# Altium schematic sheet USB.SchDoc
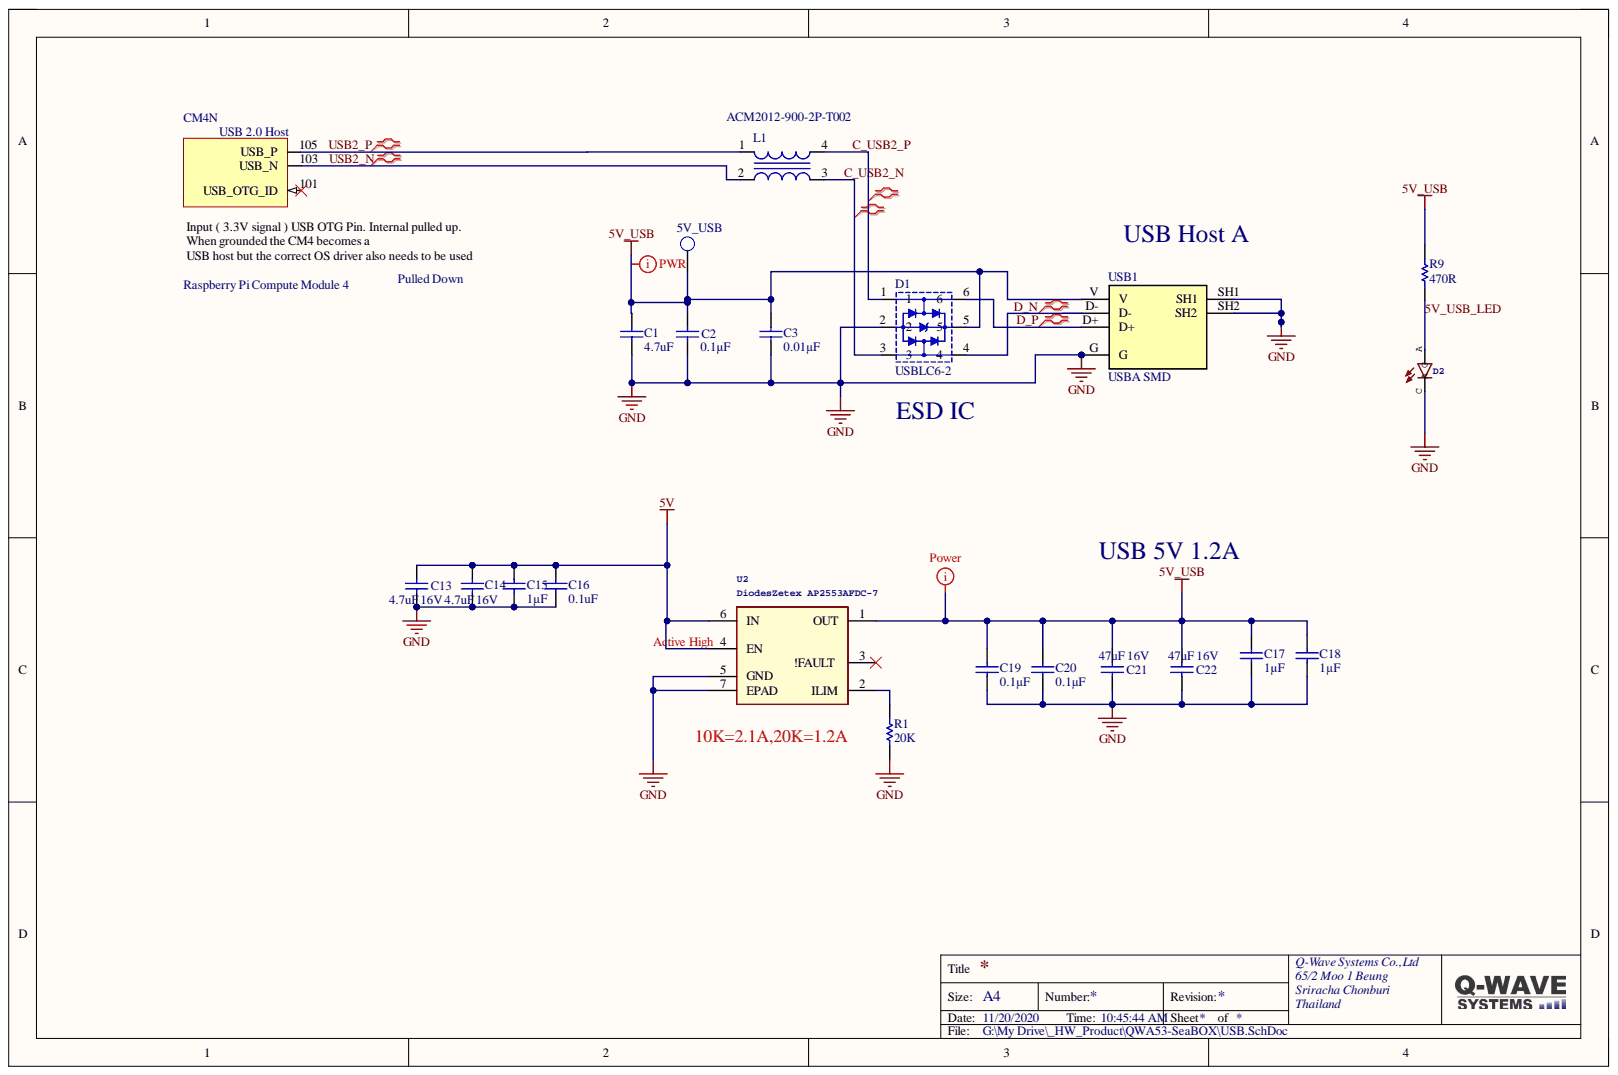
[diagram p0: USB.SchDoc]

## Parts
1  5V_USB  [TEST_POINT]
C1  4.7uF  [CAP_0805]
C2  0.1µF  [CAP_0603]
C3  0.01µF  [CAP_0603]
C13  4.7uF 16V  [CAP_0805]
C14  4.7uF 16V  [CAP_0805]
C15  1µF  [CAP_0603]
C16  0.1uF  [CAP_0603]
C17  1µF  [CAP_0603]
C18  1µF  [CAP_0603]
C19  0.1µF  [CAP_0603]
C20  0.1µF  [CAP_0603]
C21  47uF 16V  value 47uF  [1210C-GENERIC]
C22  47uF 16V  value 47uF  [1210C-GENERIC]
CM4  Raspberry Pi Compute Module 4  [CM4-F40C-100DS-0.4v_1.5mm_0mm]  (part N)
D1  USBLC6-2  [SOT-666]
D2  Red SMD LED    Used in manufacturing of most products at SparkFun   Packages: 0603 - SMD 0603 package [Product Link]  0603 SMART - SMD 0603 SMART package ( more efficient and expensive)[Product Link]   1206 - SMD1206  package [Product Link]    SparkFun Products: Pro Mini 328 -5V  RedBoard  IOIO-OTG  [LED-1206-LED_AL-S1204SRC50-14M]  (value not drawn)
L1  ACM2012-900-2P-T002  [ACM2012]
R1  20K  [RES_0603]
R9  470R  [RES_0603]
U2  DiodesZetex AP2553AFDC-7  [SON65P201X201X63-7N]
USB1  USBA SMD  [USB-A/S_B]

## Nets
5V: C13.1 C14.1 C15.2 C16.2 U2.4(EN) U2.6(IN)
5V_USB: 1.1 C1.1 C2.1 C3.2 C17.2 C18.2 C19.2 C20.2 C21.2 C22.2 D1.5 R9.2 U2.1(OUT) USB1.V
GND: C1.2 C2.2 C3.1 C13.2 C14.2 C15.1 C16.1 C17.1 C18.1 C19.1 C20.1 C21.1 C22.1 D1.2 D2.C R1.1 U2.5(GND) U2.7(EPAD) USB1.G USB1.SH1 USB1.SH2
5V_USB_LED: D2.A R9.1
C_USB2_N: D1.3 L1.3
C_USB2_P: D1.1 L1.4
D_N: D1.4 USB1.D-
D_P: D1.6 USB1.D+
USB2_N: CM4.103 L1.2
USB2_P: CM4.105 L1.1
NetR1_2: R1.2 U2.2(ILIM)

Not connected: CM4 101; U2 3(!FAULT)

## Library data of the parts
1 = TEST_POINT: Test Point; Component Kind: Standard; Library Name: Misc.SCHLIB
C1 C13 C14 = Cap: 4.7µF ±10% 16V Ceramic Capacitor X5R 0805; MFG: KEMET; MFG P/N: C0603C475K8PACTU; Component Kind: Standard; Library Name: Miscellaneous Devices.IntLib; Signal Integrity: Cap; Simulation: CAP
C2 C16 = Cap Semi: 0.1µF ±5% 50V Ceramic Capacitor X7R 0603; MFG: KEMET; MFG P/N: C0603C104J5RACTU; Component Kind: Standard; Library Name: Miscellaneous Devices.IntLib; Signal Integrity: Cap Semi; Simulation: CAP
C3 = Cap Semi: 0.01µF ±5% 50V Ceramic Capacitor X7R 0603; MFG: KEMET; MFG P/N: C0603C104J5RACTU; Component Kind: Standard; Library Name: Miscellaneous Devices.IntLib; Signal Integrity: Cap Semi; Simulation: CAP
C15 C17 C18 = Cap Semi: 1µF ±5% 50V Ceramic Capacitor X7R 0603; MFG: KEMET; MFG P/N: C0603C104J5RACTU; Component Kind: Standard; Library Name: Miscellaneous Devices.IntLib; Signal Integrity: Cap Semi; Simulation: CAP
C19 C20 = Cap Semi: 0.11µF ±5% 50V Ceramic Capacitor X7R 0603; MFG: KEMET; MFG P/N: C0603C104J5RACTU; Component Kind: Standard; Library Name: Miscellaneous Devices.IntLib; Signal Integrity: Cap Semi; Simulation: CAP
C21 C22 = Cap: Capacitor 1210; Part Number: 0805F476M100NT; Component Kind: Standard; LatestRevisionDate: 17-Jul-2002; LatestRevisionNote: Re-released for DXP Platform.; Library Name: Miscellaneous Devices.IntLib; PackageDescription: Radial Cap, Thru-Hole; 2 Leads; 0.3 in Pin Spacing; PackageReference: RAD-0.3; Published: 8-Jun-2000; Signal Integrity: Cap; Simulation: CAP; Supplier: LCSC; Publisher: Altium Limited
CM4 = CM4: Component Kind: Standard; Description: Raspberry Pi Compute Module 4; Library Name: CM4.SchLib
D1 = USBLC6-2: TVS DIODE 5.25V 17V SOT23-6; Component Kind: Standard; Library Name: x-io.SchLib
D2 = LED-RED1206: LED Red SMD ES P/N 0983-0003-2; DeviceName: 1206; GateName_1: G$1; PROD_ID: DIO-09912; Component Kind: Standard; DeviceSetName: LED-RED; Library Name: SparkFun-LED.IntLib; LibraryName: SparkFun-LED; SymbolName_1: LED
L1 = ACM2012-900-2P-T002: CHOKE COMM MODE 90 OHM .4A SMD; Manufacturer: TDK Corporation; .Checked PCB: RF; Current: 400mA; DC Resistance (DCR): 190 mOhm Max; Filter Type: Data, Signal Line; Impedance: 90 Ohm; Number of Lines: 2; Power (Watts): 0.063W, 1/16W; Resistance (Ohms): 8.2K; Temperature Coefficient: ฑ100ppm/ฐC; Tolerance: ฑ1%; Category: Filters; Component Kind: Standard; ComponentLink1Description: http://www.tdk.co.jp/tefe02/e9719_acm2012.pdf; ComponentLink1URL: http://www.tdk.co.jp/tefe02/e9719_acm2012.pdf; ComponentLink2Description: http://www.yageo.com/documents/recent/PYu-RC0402_51_RoHS_L_6.pdf; ComponentLink2URL: http://www.yageo.com/documents/recent/PYu-RC0402_51_RoHS_L_6.pdf; Composition: Thick Film; Features: -; Height: 0.016" (0.40mm); Height (Max): 0.051" (1.30mm); Inductance: -; Manufacturer Part Number: ACM2012-900-2P-T002; Mounting Type: Surface Mount; Number of Terminations: 2; Operating Temperature: -25ฐC ~ 85ฐC; Package / Case: 0805 (2012 Metric), 4 Lead; Packaging: Cut Tape (CT); Pricing 1: 1=0.48, 10=0.448, 50=0.42, 100=0.364, 500=0.308, 1000=0.252 (USD); RoHS: RoHS Compliant; Size / Dimension: 0.079" L x 0.047" W (2.00mm x 1.20mm); Stock 1: 175768; Supplier 1: Digi-Key; Supplier Part Number 1: 445-2207-1-ND; .Checked SCH: RF; Library Name: iMX6 Rex Development Baseboard V1I1.IntLib
R1 = Res Semi: Resistor 0603; Component Kind: Standard; Library Name: Miscellaneous Devices.IntLib; Signal Integrity: Res Semi; Simulation: RES
R9 = Res Semi: 470R Resistor 0603; Component Kind: Standard; Library Name: SCH_Passive.SchLib; Signal Integrity: Res Semi; Simulation: RES
U2 = AP2553AFDC-7: Power Distribution 2.1A Precision ADJ 5.5V 2.1A; Manufacturer_Name: Diodes Inc.; GateName_1: G$1; Component Kind: Standard; DeviceSetName: AP2553AFDC-7; Height: 0.63mm; Library Name: AP2553AFDC-7.IntLib; LibraryName: AP2553AFDC-7; Manufacturer_Part_Number: AP2553AFDC-7; Mouser_Part_Number: 621-AP2553AFDC-7; Mouser_Price-Stock: https://www.mouser.com/Search/Refine.aspx?Keyword=621-AP2553AFDC-7; SymbolName_1: AP2553AFDC-7
USB1 = USBA SMD: UMRA04FTWNY-03; ES P/N: 0007-1463-7; Component Kind: Standard; ES Link: https://www.es.co.th/detail.asp?Prod=000714637; P/N: UMRA04FTWNY-03; Library Name: QwaveLib.SchLib
